# Altium schematic sheet power.SchDoc
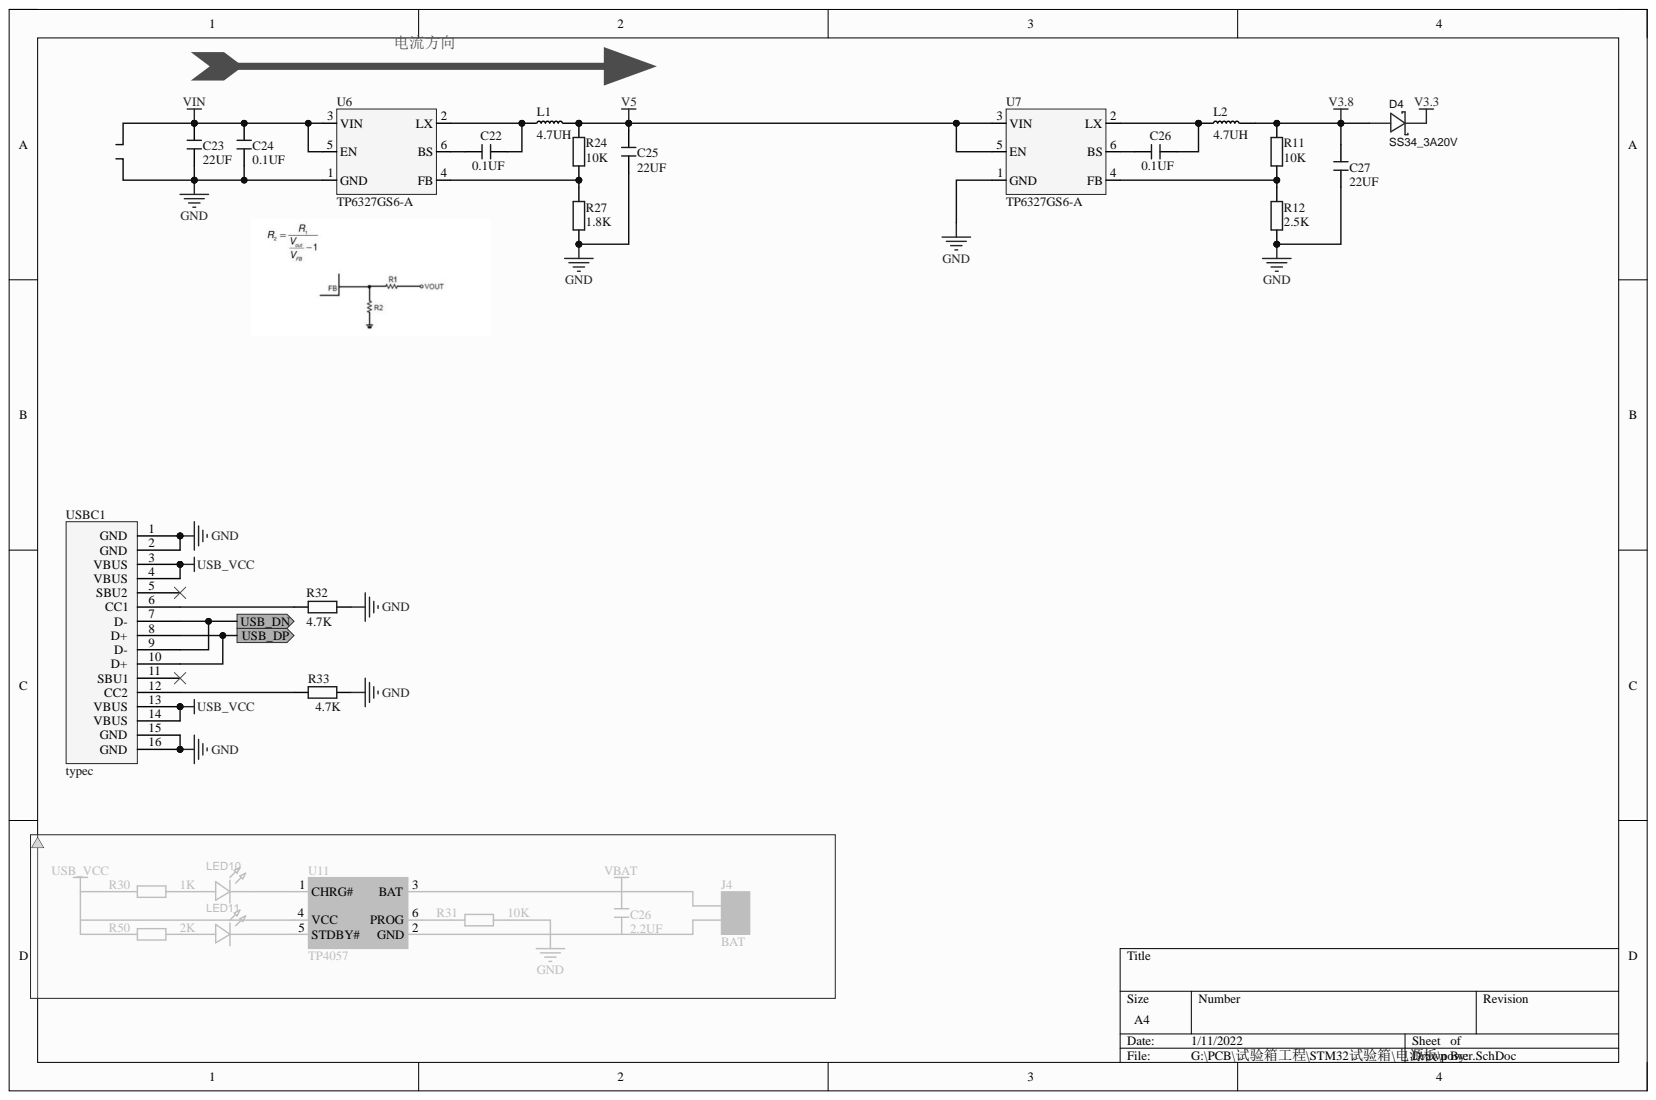
[diagram p0: power.SchDoc]

## Parts
C22  0.1UF  [C 0603_M]
C23  22UF  [C 0805_L]
C24  0.1UF  [C 0603_M]
C25  22UF  [C 0805_L]
C26  0.1UF  [C 0603_M]
C27  22UF  [C 0805_L]
D4  SS34_3A20V  [SOD-123]
L1  4.7UH  [CD42]
L2  4.7UH  [CD42]
R11  10K  [0603]
R12  2.5K  [0603]
R24  10K  [0603]
R27  1.8K  [0603]
R32  4.7K  [R 0603_L]
R33  4.7K  [R 0603_L]
U6  TP6327GS6-A  [SOT23-6N]
U7  TP6327GS6-A  [SOT23-6N]
USBC1  typec  [MY-USB-TYPEC_16PIN]

## Ports
USB_DN, USB_DP

## Nets
GND: C23.1 C24.1 C25.1 C27.1 R12.2 R27.2 R32.1 R33.2 U6.1(GND) U7.1(GND) USBC1.1(GND) USBC1.2(GND) USBC1.15(GND) USBC1.16(GND)
USB_VCC: USBC1.3(VBUS) USBC1.4(VBUS) USBC1.13(VBUS) USBC1.14(VBUS)
V3.3: D4.1
V3.8: C27.2 D4.2 L2.2 R11.2
V5: C25.2 L1.2 R24.2 U7.3(VIN) U7.5(EN)
VIN: C23.2 C24.2 U6.3(VIN) U6.5(EN)
USB_DN: USBC1.7(D-) USBC1.9(D-) ; port USB_DN
USB_DP: USBC1.8(D+) USBC1.10(D+) ; port USB_DP
NetC22_1: C22.1 U6.6(BS)
NetC22_2: C22.2 L1.1 U6.2(LX)
NetC26_1: C26.1 U7.6(BS)
NetC26_2: C26.2 L2.1 U7.2(LX)
NetR11_1: R11.1 R12.1 U7.4(FB)
NetR24_1: R24.1 R27.1 U6.4(FB)
NetR32_2: R32.2 USBC1.6(CC1)
NetR33_1: R33.1 USBC1.12(CC2)

Not connected: USBC1 5(SBU2) 11(SBU1)

## Library data of the parts
C22 C24 C26 = C: 贴片电容; BOM名称: 无极性贴片电容; 优秀评价可免费更新: 联系淘宝ID：黄晶宝石，获取更新版封装库; 卖家免费技术支持，联系售后: 唯一出售店铺网址https://shop463601444.taobao.com; 本封装库来自淘宝卖家 ID：黄晶宝石: 其他店铺购入均为盗版，请立即申请退款; Component Kind: Standard; Library Name: 网关通版pcb文件.IntLib
C23 C25 C27 = C: 三星 C602037; BOM名称: 无极性贴片电容; 优秀评价可免费更新: 联系淘宝ID：黄晶宝石，获取更新版封装库; 卖家免费技术支持，联系售后: 唯一出售店铺网址https://shop463601444.taobao.com; 本封装库来自淘宝卖家 ID：黄晶宝石: 其他店铺购入均为盗版，请立即申请退款; Component Kind: Standard; Library Name: 网关通版pcb文件.IntLib
D4 = SS34_3A20V: BOM_Manufacturer: LGE; BOM_Manufacturer Part: SS34; Contributor: LCSC; BOM_JLCPCB Part Class: Extended Part; BOM_Supplier: LCSC; BOM_Supplier Part: C432300; Component Kind: Standard; spicePre: D; spiceSymbolName: SS34_C432300; Library Name: LoRa节点板.IntLib
L1 L2 = L: Component Kind: Standard; Library Name: wanguan.SchLib
R11 R12 R24 R27 = R: 1%精密电阻; BOM名称: 贴片电阻; 优秀评价可免费更新: 联系淘宝ID：黄晶宝石，获取更新版封装库; 卖家免费技术支持，联系售后: 唯一出售店铺网址https://shop463601444.taobao.com; 本封装库来自淘宝卖家 ID：黄晶宝石: 其他店铺购入均为盗版，请立即申请退款; Component Kind: Standard; Library Name: 网关通版pcb文件.IntLib
R32 R33 = R: 贴片电阻; 封装库来自淘宝卖家 ID：黄晶宝石: 其他店铺购入均为盗版，不享受售后技术支持服务，请立即申请退款; 版权归属: 来自淘宝 ID：黄晶宝石; BOM名称: 贴片电阻; Component Kind: Standard; Library Name: 0.1 - 电阻-电容-电感.SchLib; 联系售后: https://shop463601444.taobao.com
U6 U7 = TP6327GS6-A: 3.5~18v DCDC模块; Component Kind: Standard; Library Name: 基础板.SchLib
USBC1 = typec座子: 仅usb2.0; Component Kind: Standard; Library Name: 网关通版pcb文件.IntLib
